# Altium schematic sheet 06_Relays_and_SolenoidValves.SchDoc
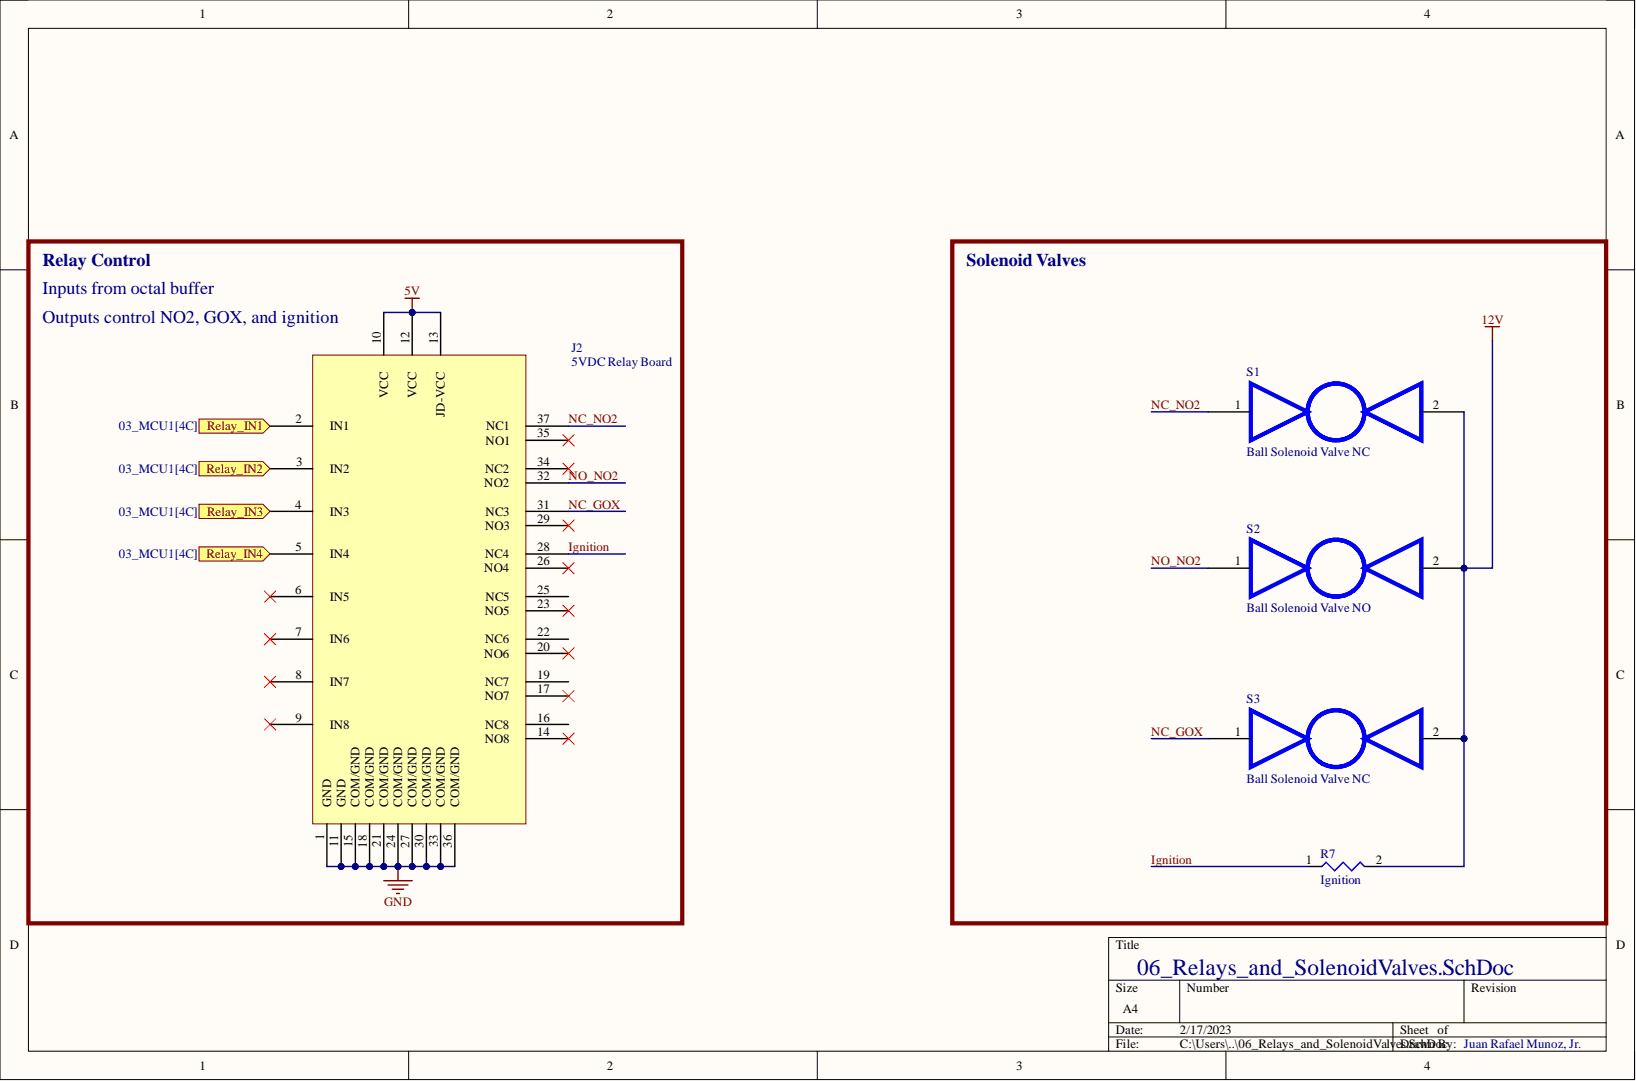
[diagram p0: 06_Relays_and_SolenoidValves.SchDoc]

## Parts
J2  5VDC Relay Board  [ELEGOO Relay Board]
R7  Ignition  [Ignition]
S1  Ball Solenoid Valve NC  [Ball Solenoid Valve NC]
S2  Ball Solenoid Valve NO  [Ball Solenoid Valve NO]
S3  Ball Solenoid Valve NC  [Ball Solenoid Valve NC]

## Ports
Relay_IN1, Relay_IN2, Relay_IN3, Relay_IN4

## Nets
5V: J2.10(VCC) J2.12(VCC) J2.13(JD-VCC)
12V: R7.2 S1.2 S2.2 S3.2
GND: J2.1(GND) J2.11(GND) J2.15(COM/GND) J2.18(COM/GND) J2.21(COM/GND) J2.24(COM/GND) J2.27(COM/GND) J2.30(COM/GND) J2.33(COM/GND) J2.36(COM/GND)
Ignition: J2.28(NC4) R7.1
NC_GOX: J2.31(NC3) S3.1
NC_NO2: J2.37(NC1) S1.1
NO_NO2: J2.32(NO2) S2.1
Relay_IN1: J2.2(IN1) ; port Relay_IN1
Relay_IN2: J2.3(IN2) ; port Relay_IN2
Relay_IN3: J2.4(IN3) ; port Relay_IN3
Relay_IN4: J2.5(IN4) ; port Relay_IN4

Not connected: J2 6(IN5) 7(IN6) 8(IN7) 9(IN8) 14(NO8) 16(NC8) 17(NO7) 19(NC7) 20(NO6) 22(NC6) 23(NO5) 25(NC5) 26(NO4) 29(NO3) 34(NC2) 35(NO1)

## Library data of the parts
J2 = ELEGOO Relay Board: Component Kind: Standard; Library Name: Ground Support_Schlib.SchLib
R7 = Ignition: Ignite rocket. Unknown resistance.; Component Kind: Standard; Library Name: Ground Support_Schlib.SchLib
S1 S3 = Ball Solenoid Valve NC: Component Kind: Standard; Library Name: Ground Support_Schlib.SchLib
S2 = Ball Solenoid Valve NO: Component Kind: Standard; Library Name: Ground Support_Schlib.SchLib
